# Altium schematic sheet Configuration Switches and DAC Rev 6.SchDoc("Configuration Switches and DAC Rev 6")
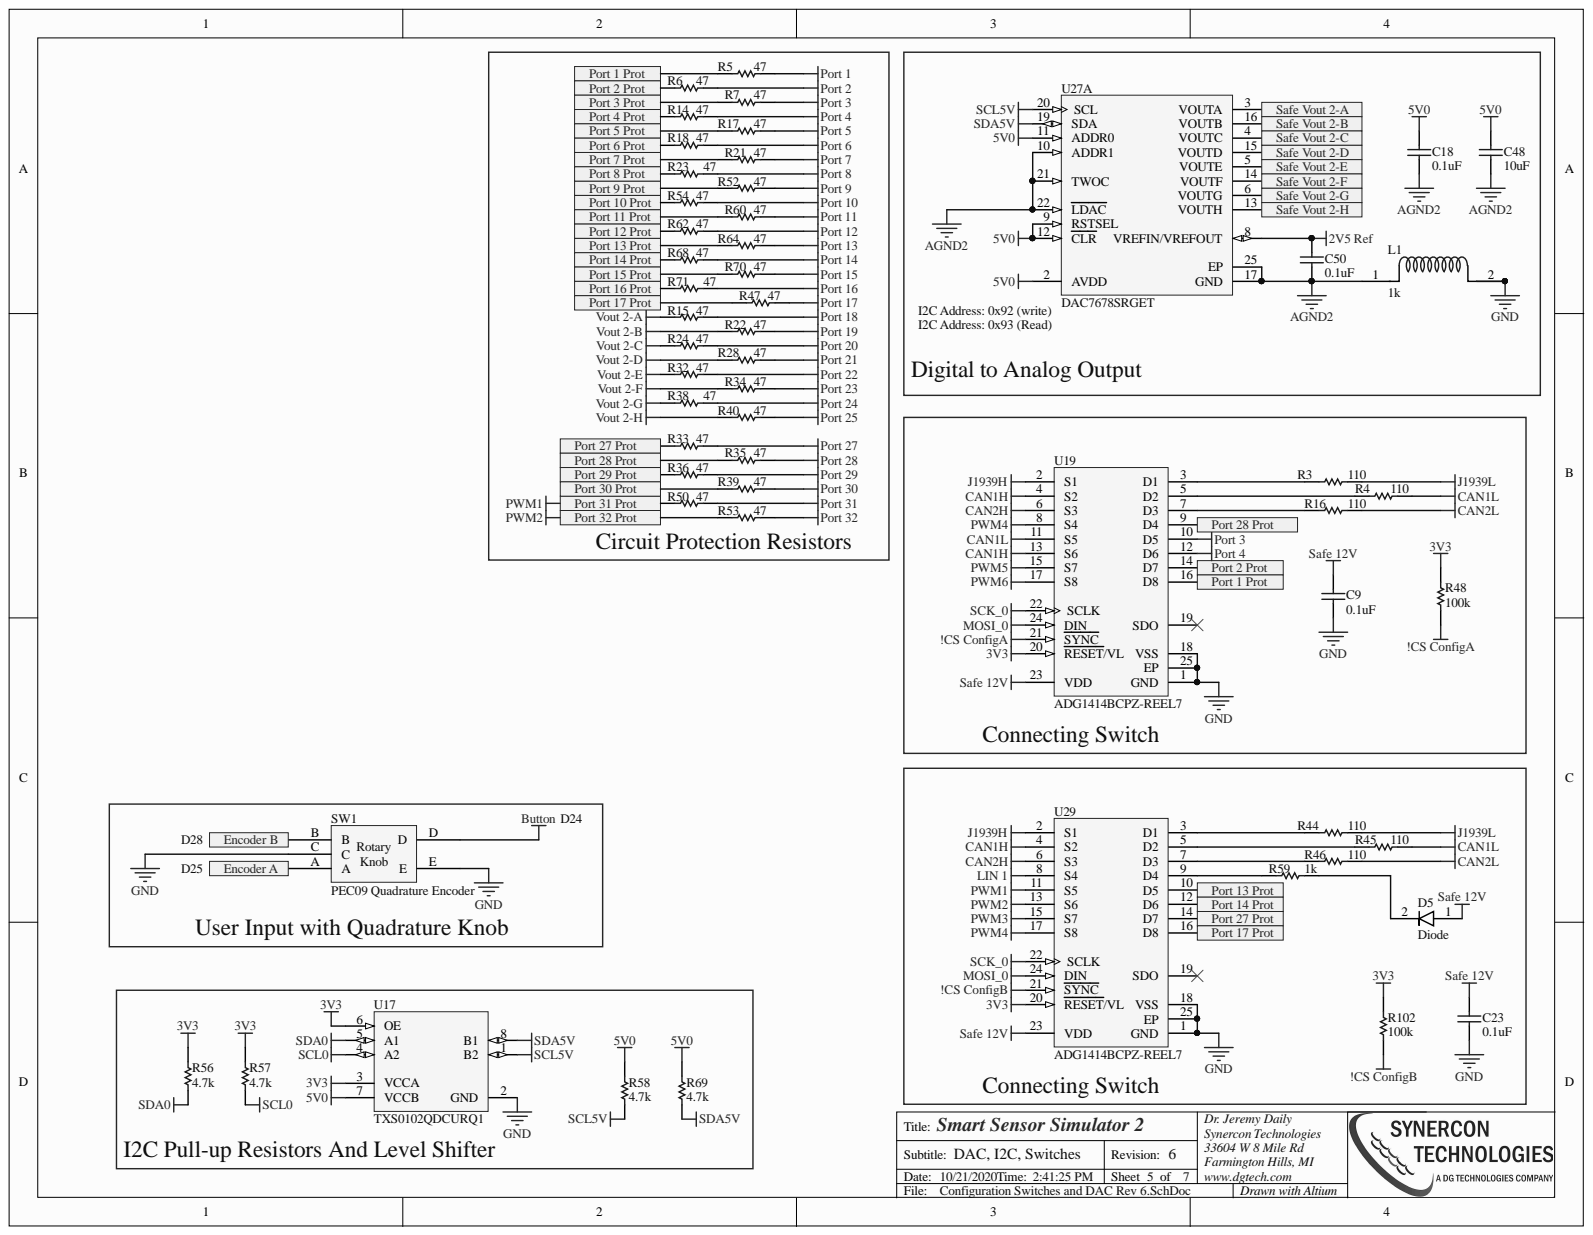
[diagram p0: Configuration Switches and DAC Rev 6.SchDoc]

## Parts
C9  0.1uF  [0603]
C18  0.1uF  [0603]
C23  0.1uF  [0603]
C48  10uF  [0603]
C50  0.1uF  [0603]
D5  Diode  [CST2C]
L1  1k  [0603]
R3  110  [0603]
R4  110  [0603]
R5  47  [0603]
R6  47  [0603]
R7  47  [0603]
R14  47  [0603]
R15  47  [0603]
R16  110  [0603]
R17  47  [0603]
R18  47  [0603]
R21  47  [0603]
R22  47  [0603]
R23  47  [0603]
R24  47  [0603]
R28  47  [0603]
R32  47  [0603]
R33  47  [0603]
R34  47  [0603]
R35  47  [0603]
R36  47  [0603]
R38  47  [0603]
R39  47  [0603]
R40  47  [0603]
R44  110  [0603]
R45  110  [0603]
R46  110  [0603]
R47  47  [0603]
R48  100k  [0603]
R50  47  [0603]
R52  47  [0603]
R53  47  [0603]
R54  47  [0603]
R56  4.7k  [0603]
R57  4.7k  [0603]
R58  4.7k  [0603]
R59  1k  [0603]
R60  47  [0603]
R62  47  [0603]
R64  47  [0603]
R68  47  [0603]
R69  4.7k  [0603]
R70  47  [0603]
R71  47  [0603]
R102  100k  [0603]
SW1  PEC09 Quadrature Encoder  [PEC09 Series Encoder]
U17  TXS0102QDCURQ1  [DCU0008A_L]
U19  ADG1414BCPZ-REEL7  [ADI-CP-24-3_M]
U27  DAC7678SRGET  [TI-RGE24-2700X2700TP_V]  (part A)
U29  ADG1414BCPZ-REEL7  [ADI-CP-24-3_M]

## Ports
Encoder A, Encoder B, Port 1 Prot, Port 2 Prot, Port 3 Prot, Port 4 Prot, Port 5 Prot, Port 6 Prot, Port 7 Prot, Port 8 Prot, Port 9 Prot, Port 10 Prot, Port 11 Prot, Port 12 Prot, Port 13 Prot, Port 14 Prot, Port 15 Prot, Port 16 Prot, Port 17 Prot, Port 27 Prot, Port 28 Prot, Port 29 Prot, Port 30 Prot, Port 31 Prot, Port 32 Prot, Safe Vout 2-A, Safe Vout 2-B, Safe Vout 2-C, Safe Vout 2-D, Safe Vout 2-E, Safe Vout 2-F, Safe Vout 2-G, Safe Vout 2-H

## Nets
2V5 Ref: C50.2 U27.8(VREFIN/VREFOUT)
3V3: R48.2 R56.2 R57.2 R102.2 U17.3(VCCA) U17.6(OE) U19.20(RESET/VL) U29.20(RESET/VL)
5V0: C18.2 C48.2 R58.2 R69.2 U17.7(VCCB) U27.2(AVDD) U27.9(RSTSEL) U27.11(ADDR0) U27.12(CLR)
!CS ConfigA: R48.1 U19.21(SYNC)
!CS ConfigB: R102.1 U29.21(SYNC)
AGND2: C18.1 C48.1 C50.1 L1.1 U27.10(ADDR1) U27.17(GND) U27.21(TWOC) U27.22(LDAC) U27.25(EP)
Button: SW1.D
CAN1H: U19.4(S2) U19.13(S6) U29.4(S2)
CAN1L: R4.1 R45.1 U19.11(S5)
CAN2H: U19.6(S3) U29.6(S3)
CAN2L: R16.2 R46.2
GND: C9.1 C23.1 L1.2 SW1.C SW1.E U17.2(GND) U19.1(GND) U19.18(VSS) U19.25(EP) U29.1(GND) U29.18(VSS) U29.25(EP)
J1939H: U19.2(S1) U29.2(S1)
J1939L: R3.1 R44.1
LIN 1: U29.8(S4)
MOSI_0: U19.24(DIN) U29.24(DIN)
Port 1: R5.1
Port 2: R6.1
Port 3: R7.1 U19.10(D5)
Port 4: R14.1 U19.12(D6)
Port 5: R17.1
Port 6: R18.1
Port 7: R21.1
Port 8: R23.2
Port 9: R52.1
Port 10: R54.1
Port 11: R60.1
Port 12: R62.1
Port 13: R64.1
Port 14: R68.1
Port 15: R70.1
Port 16: R71.2
Port 17: R47.1
Port 18: R15.1
Port 19: R22.1
Port 20: R24.1
Port 21: R28.1
Port 22: R32.1
Port 23: R34.1
Port 24: R38.2
Port 25: R40.1
Port 27: R33.1
Port 28: R35.1
Port 29: R36.1
Port 30: R39.1
Port 31: R50.1
Port 32: R53.1
PWM3: U29.15(S7)
PWM4: U19.8(S4) U29.17(S8)
PWM5: U19.15(S7)
PWM6: U19.17(S8)
Safe 12V: C9.2 C23.2 D5.1 U19.23(VDD) U29.23(VDD)
SCK_0: U19.22(SCLK) U29.22(SCLK)
SCL0: R57.1 U17.4(A2)
SCL5V: R58.1 U17.1(B2) U27.20(SCL)
SDA0: R56.1 U17.5(A1)
SDA5V: R69.1 U17.8(B1) U27.19(SDA)
Vout 2-A: R15.2
Vout 2-B: R22.2
Vout 2-C: R24.2
Vout 2-D: R28.2
Vout 2-E: R32.2
Vout 2-F: R34.2
Vout 2-G: R38.1
Vout 2-H: R40.2
Encoder A: SW1.A ; port Encoder A
Encoder B: SW1.B ; port Encoder B
Port 1 Prot: R5.2 U19.16(D8) ; port Port 1 Prot
Port 2 Prot: R6.2 U19.14(D7) ; port Port 2 Prot
Port 3 Prot: R7.2 ; port Port 3 Prot
Port 4 Prot: R14.2 ; port Port 4 Prot
Port 5 Prot: R17.2 ; port Port 5 Prot
Port 6 Prot: R18.2 ; port Port 6 Prot
Port 7 Prot: R21.2 ; port Port 7 Prot
Port 8 Prot: R23.1 ; port Port 8 Prot
Port 9 Prot: R52.2 ; port Port 9 Prot
Port 10 Prot: R54.2 ; port Port 10 Prot
Port 11 Prot: R60.2 ; port Port 11 Prot
Port 12 Prot: R62.2 ; port Port 12 Prot
Port 13 Prot: R64.2 U29.10(D5) ; port Port 13 Prot
Port 14 Prot: R68.2 U29.12(D6) ; port Port 14 Prot
Port 15 Prot: R70.2 ; port Port 15 Prot
Port 16 Prot: R71.1 ; port Port 16 Prot
Port 17 Prot: R47.2 U29.16(D8) ; port Port 17 Prot
Port 27 Prot: R33.2 U29.14(D7) ; port Port 27 Prot
Port 28 Prot: R35.2 U19.9(D4) ; port Port 28 Prot
Port 29 Prot: R36.2 ; port Port 29 Prot
Port 30 Prot: R39.2 ; port Port 30 Prot
PWM1: R50.2 U29.11(S5) ; port Port 31 Prot
PWM2: R53.2 U29.13(S6) ; port Port 32 Prot
Safe Vout 2-A: U27.3(VOUTA) ; port Safe Vout 2-A
Safe Vout 2-B: U27.16(VOUTB) ; port Safe Vout 2-B
Safe Vout 2-C: U27.4(VOUTC) ; port Safe Vout 2-C
Safe Vout 2-D: U27.15(VOUTD) ; port Safe Vout 2-D
Safe Vout 2-E: U27.5(VOUTE) ; port Safe Vout 2-E
Safe Vout 2-F: U27.14(VOUTF) ; port Safe Vout 2-F
Safe Vout 2-G: U27.6(VOUTG) ; port Safe Vout 2-G
Safe Vout 2-H: U27.13(VOUTH) ; port Safe Vout 2-H
NetD5_2: D5.2 R59.1
NetR3_2: R3.2 U19.3(D1)
NetR4_2: R4.2 U19.5(D2)
NetR16_1: R16.1 U19.7(D3)
NetR44_2: R44.2 U29.3(D1)
NetR45_2: R45.2 U29.5(D2)
NetR46_1: R46.1 U29.7(D3)
NetR59_2: R59.2 U29.9(D4)

Not connected: U19 19(SDO); U29 19(SDO)

## Library data of the parts
C9 C18 C23 C50 = Cap: CAP CER 0.1UF 50V 10% X7R 0603; PartNumber: GRM188R72A104KA35D; Manufacturer: Murata Electronics; Alternative: Acceptable; Supplier Part Number 1: 490-3285-1-ND; Supplier 1: Digi-Key; Simulation: CAP; Signal Integrity: Cap; Library Name: SSS Schematic Library.SchLib; Component Kind: Standard
C48 = Cap: 10µF ±20% 25V Ceramic Capacitor X5R 0603 (1608 Metric); PartNumber: GRT188R61E106ME13D; Manufacturer: Murata Electronics North America; Supplier Part Number 1: 490-12323-1-ND; Supplier 1: Digi-Key; Simulation: CAP; Signal Integrity: Cap; Library Name: SSS Integrated Library.IntLib; Component Kind: Standard
D5 = Diode: Diode Schottky 40V 2A Surface Mount DO-214AC (SMA); PartNumber: CCS15S30L3FCT-ND; Manufacturer: Toshiba Semiconductor and Storage; Supplier Part Number 1: CCS15S30L3FCT-ND; Supplier 1: Digi-Key; Simulation: DIODE; Signal Integrity: Diode; Library Name: SSS Integrated Library.IntLib; Component Kind: Standard
L1 = Ferrite Bead: Ferrite Bead 80ohms 100MHz 5A Monolithic 0805 SMD; PartNumber: ERJ-3EKF1001V; Manufacturer: Panasonic Electronic Components; Alternative: Acceptable; Supplier Part Number 1: P1.00KHCT-ND; Supplier 1: Digi-Key; Library Name: SSS Integrated Library.IntLib; Component Kind: Standard
R3 R4 R16 R44-R46 = Chip Resistor: RES SMD 110 OHM 1% 1/10W 0603; PartNumber: ERJ-3EKF1100V; Manufacturer: Panasonic Electronic Components; Supplier Part Number 1: P110HCT-ND; Supplier 1: Digi-Key; Library Name: SSS Schematic Library.SchLib; Component Kind: Standard
R5-R7 R14 R15 R17 R18 R21-R24 R28 R32-R36 R38-R40 R47 R50 R52-R54 R60 R62 R64 R68 R70 R71 = Chip Resistor: 47 Ohms ±5% 0.25W, 1/4W Chip Resistor 0603 (1608 Metric) Automotive AEC-Q200, Pulse Withstanding Thick Film; PartNumber: ERJ-PA3J470V; Manufacturer: Panasonic Electronic Components; Supplier Part Number 1: P47.00BZCT-ND; Supplier 1: Digi-Key; Library Name: SSS Integrated Library.IntLib; Component Kind: Standard
R48 R102 = Chip Resistor: 100 kOhms ±1% 0.1W, 1/10W Chip Resistor 0603 (1608 Metric) Automotive AEC-Q200 Thick Film; PartNumber: ERJ-3EKF1003V; Manufacturer: Panasonic Electronic Components; Alternative: Acceptable; Supplier Part Number 1: P100KHCT-ND; Supplier 1: Digi-Key; Library Name: SSS Integrated Library.IntLib; Component Kind: Standard
R56-R58 R69 = Chip Resistor: RES SMD 4.7K OHM 1% 1/10W 0603; PartNumber: ERJ-3EKF4701V; Manufacturer: Panasonic Electronic Components; Supplier Part Number 1: P4.70KHCT-ND; Supplier 1: Digi-Key; Library Name: SSS Integrated Library.IntLib; Component Kind: Standard
R59 = Chip Resistor: RES SMD 1K OHM 1% 1/10W 0603; PartNumber: ERJ-3EKF1001V; Manufacturer: Panasonic Electronic Components; Alternative: Acceptable; Supplier Part Number 1: P1.00KHCT-ND; Supplier 1: Digi-Key; Library Name: SSS Schematic Library.SchLib; Component Kind: Standard
SW1 = Quadrature PEC09: ENCODER INCREMENTAL W/SWITCH 9MM; PartNumber: PEC09-2220F-S0012; Manufacturer: Bourns Inc.; Supplier Part Number 1: PEC09-2220F-S0012-ND; Supplier 1: Digi-Key; Library Name: SSS Integrated Library.IntLib; Component Kind: Standard
U17 = TXS0102QDCURQ1: Voltage Level Translator Bidirectional 1 Circuit 2 Channel 24Mbps US8; Manufacturer: Texas Instruments; Supplier Part Number 1: 296-44866-1-ND; Supplier 1: Digi-Key; PartNumber: TXS0102QDCURQ1; Library Name: TI_Logic_Little_Logic.IntLib; Component Kind: Standard
U19 U29 = ADG1414BCPZ-REEL7: 9.5 Ohm RON +/-15 V / +12 V / +/-5 V iCMOS Serially-Controlled Octal SPST Switch, -40 to 125 degC, 24-pin QFN (CP-24-3), Reel; Manufacturer: Analog Devices; Supplier Part Number 1: ADG1414BCPZ-REEL7TR-ND; Supplier 1: Digi-Key; PartNumber: ADG1414BCPZ-REEL7; Library Name: Analog Devices Switches and Multiplexers Analog Switches.IntLib; Component Kind: Standard
U27 = DAC7678SRGET: 12-Bit, Octal Channel, Ultra-Low Glitch, Voltage Output, 2-Wire Interface DAC with 2.5 V Internal Reference, -40 to 125 degC, 24-pin QFN (RGE24), Green (RoHS & no Sb/Br); Manufacturer: Texas Instruments; Supplier Part Number 1: 296-27743-1-ND; Supplier 1: Digi-Key; PartNumber: DAC7678SRGET; Component Kind: Standard; Library Name: TI Data Converters Digital to Analog Converter.IntLib
